# Altium schematic sheet 09  DT V1.0 V1.1.SchDoc
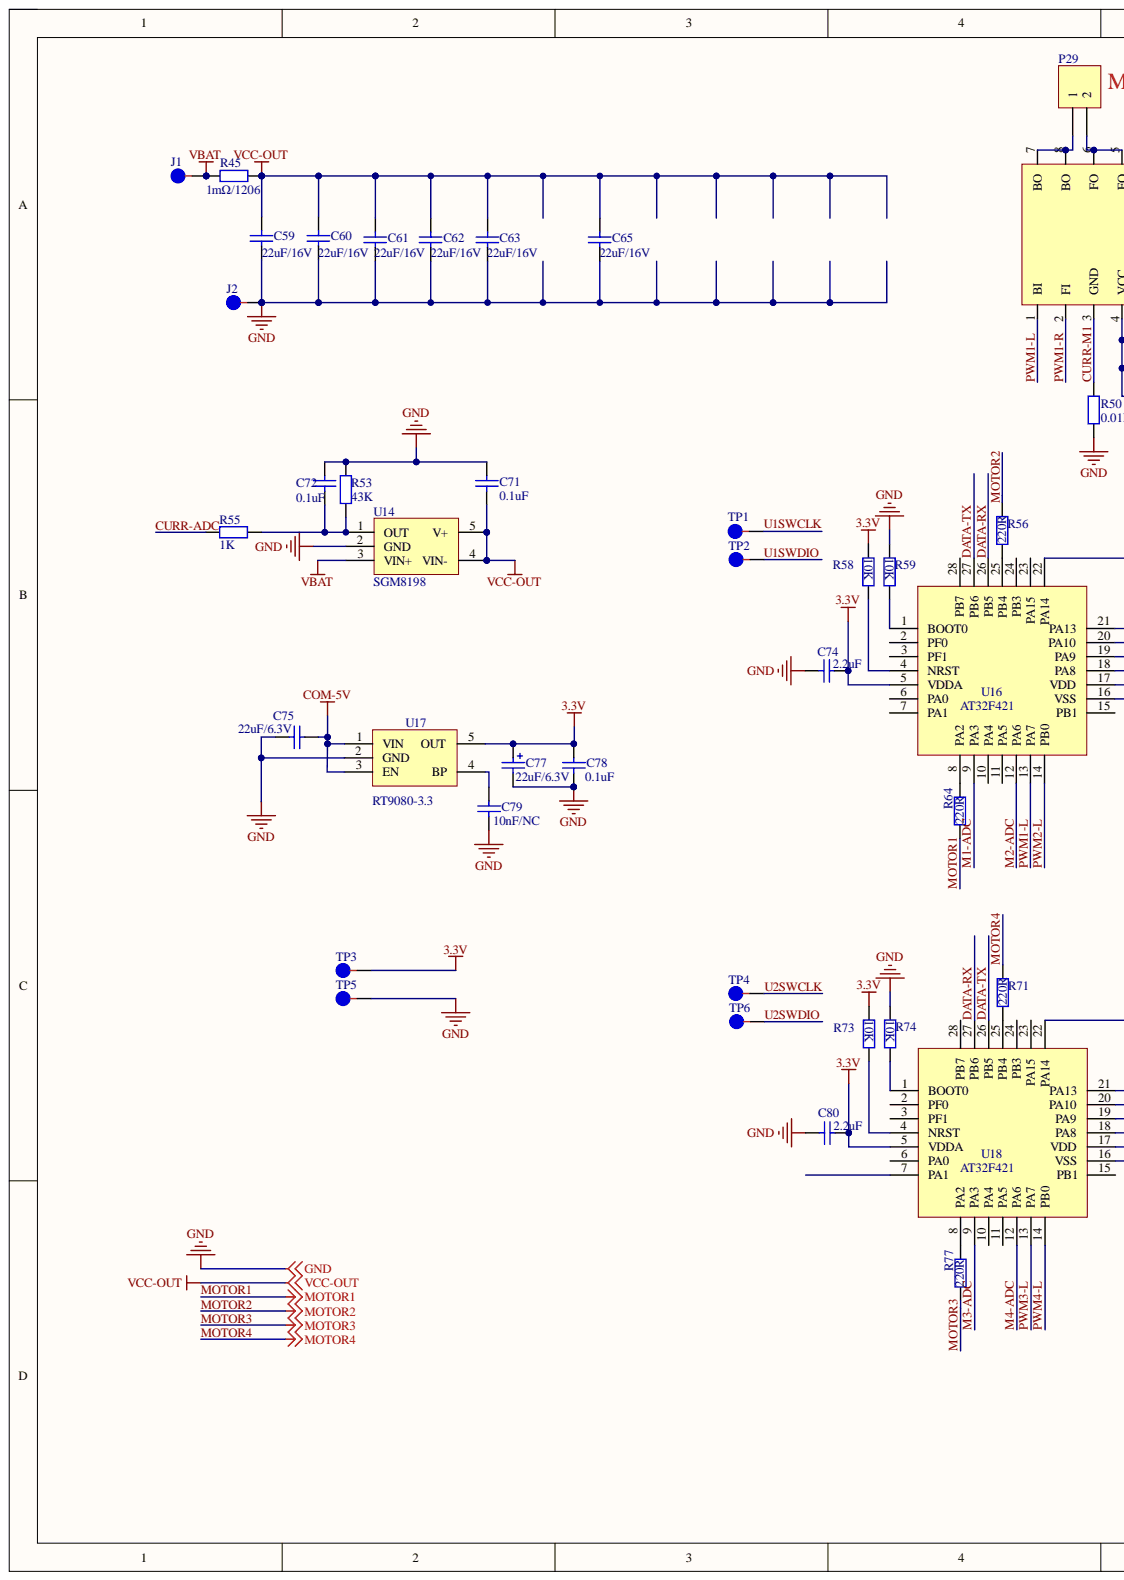
[diagram p0: 09  DT V1.0 V1.1.SchDoc, left]
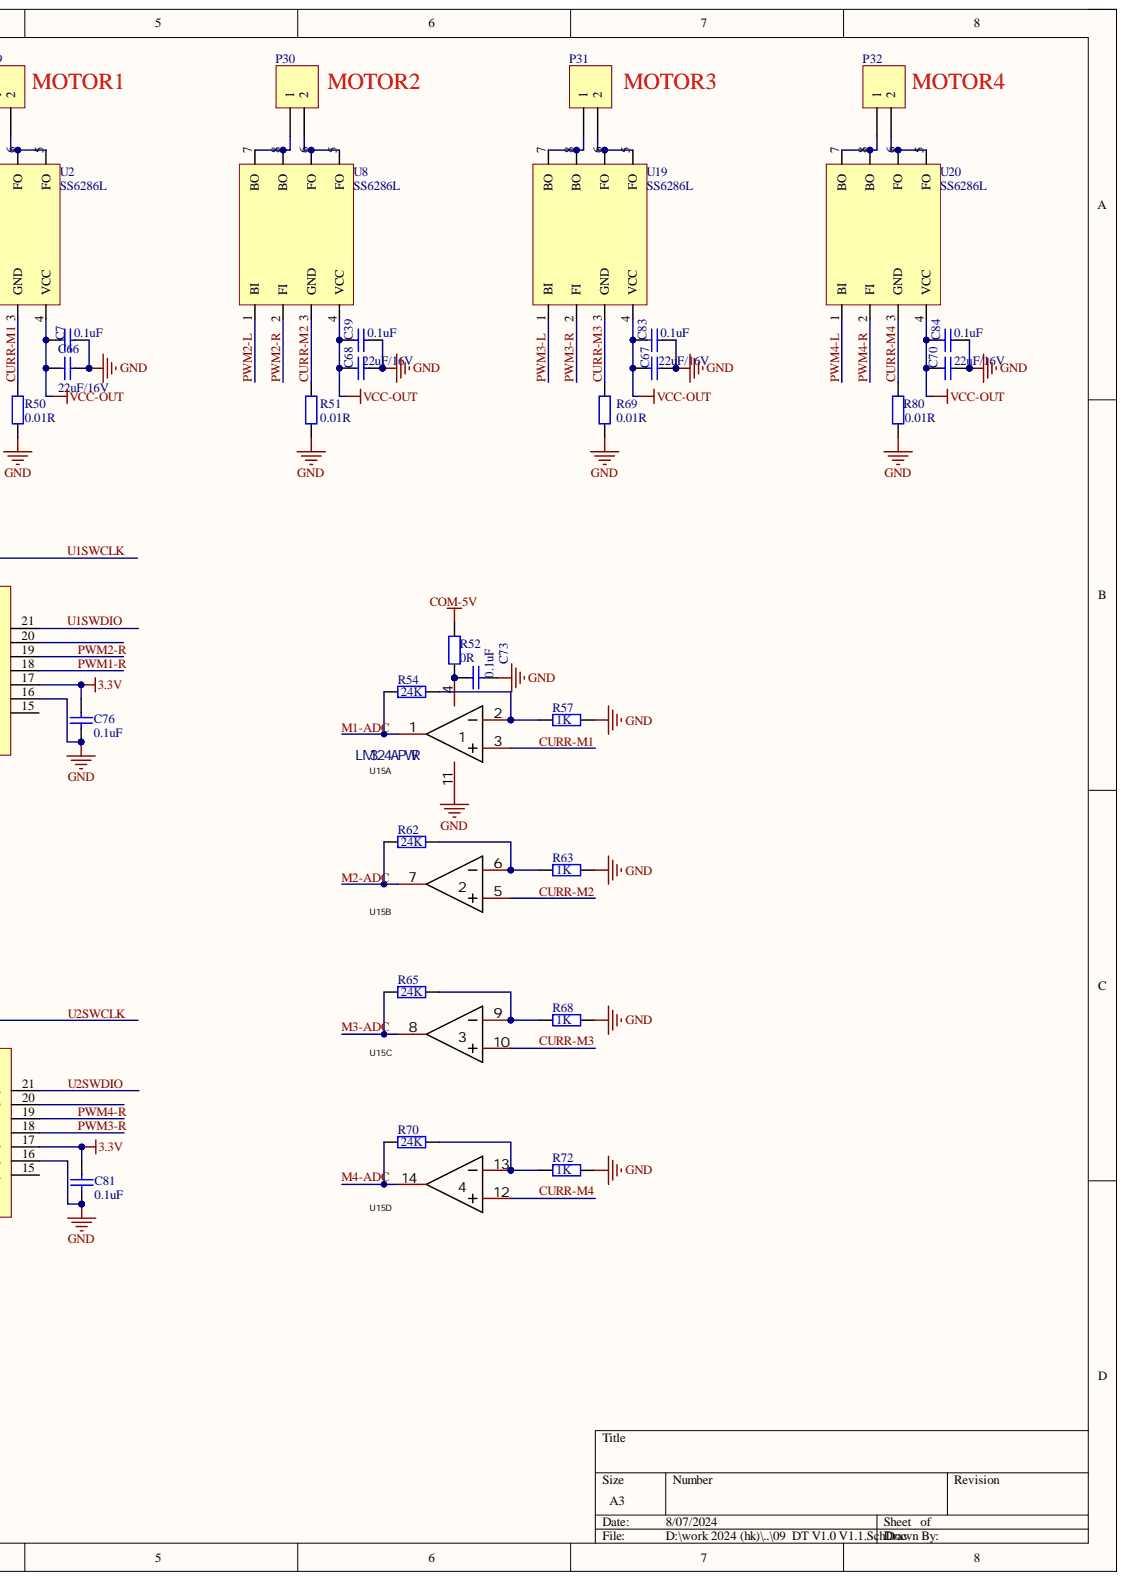
[diagram p1: 09  DT V1.0 V1.1.SchDoc, right]

## Parts
C7  0.1uF  [C0201]
C39  0.1uF  [C0201]
C59  22uF/16V  [C0603]
C60  22uF/16V  [C0603]
C61  22uF/16V  [C0603]
C62  22uF/16V  [C0603]
C63  22uF/16V  [C0603]
C65  22uF/16V  [C0603]
C66  22uF/16V  [C0603]
C67  22uF/16V  [C0603]
C68  22uF/16V  [C0603]
C70  22uF/16V  [C0603]
C71  0.1uF  [C0201]
C72  0.1uF  [C0201]
C73  0.1uF  [C0201]
C74  2.2uF  [C0201]
C75  22uF/6.3V  [C0603]
C76  0.1uF  [C0201]
C77  22uF/6.3V  [C0805-TAN]
C78  0.1uF  [C0201]
C79  10nF/NC  [C0201]
C80  2.2uF  [C0201]
C81  0.1uF  [C0201]
C83  0.1uF  [C0201]
C84  0.1uF  [C0201]
J1  (no value)  [TP08]
J2  (no value)  [TP08]
P29  Header 2  [SH1.25-2PLI]  (value not drawn)
P30  Header 2  [SH1.25-2PLI]  (value not drawn)
P31  Header 2  [SH1.25-2PLI]  (value not drawn)
P32  Header 2  [SH1.25-2PLI]  (value not drawn)
R45  1mΩ/1206  [R1206]
R50  0.01R  [R1206]
R51  0.01R  [R1206]
R52  0R  [R0201]
R53  43K  [R0201]
R54  24K  [R0201]
R55  1K  [R0201]
R56  220R  [R0201]
R57  1K  [R0201]
R58  10K  [R0201]
R59  10K  [R0201]
R62  24K  [R0201]
R63  1K  [R0201]
R64  220R  [R0201]
R65  24K  [R0201]
R68  1K  [R0201]
R69  0.01R  [R1206]
R70  24K  [R0201]
R71  220R  [R0201]
R72  1K  [R0201]
R73  10K  [R0201]
R74  10K  [R0201]
R77  220R  [R0201]
R80  0.01R  [R1206]
TP1  (no value)  [TP08]
TP2  (no value)  [TP08]
TP3  (no value)  [TP08]
TP4  (no value)  [TP08]
TP5  (no value)  [TP08]
TP6  (no value)  [TP08]
U2  SS6286L  [SS6286L-DFN8-4*4]
U8  SS6286L  [SS6286L-DFN8-4*4]
U14  SGM8198  [RT9193]
U15  LM324APWR  [TSSOP-14P]  (parts A,B,C,D)
U16  AT32F421  [QFN28-4X4]
U17  RT9080-3.3  [RT9193]
U18  AT32F421  [QFN28-4X4]
U19  SS6286L  [SS6286L-DFN8-4*4]
U20  SS6286L  [SS6286L-DFN8-4*4]

## Nets
3.3V: C74.1 C76.1 C77.1 C78.1 C80.1 C81.1 R58.1 R73.1 TP3.1 U16.5(VDDA) U16.17(VDD) U17.5(OUT) U18.5(VDDA) U18.17(VDD)
COM-5V: C75.1 R52.1 U17.1(VIN) U17.3(EN)
GND: C7.1 C39.1 C59.1 C60.1 C61.1 C62.1 C63.1 C65.1 C66.1 C67.1 C68.1 C70.1 C71.1 C72.1 C73.2 C74.2 C75.2 C76.2 C77.2 C78.2 C79.2 C80.2 C81.2 C83.1 C84.1 J2.1 R50.1 R51.1 R53.2 R57.1 R59.1 R63.1 R68.1 R69.1 R72.1 R74.1 R80.1 TP5.1 U14.2(GND) U15.11 U16.16(VSS) U17.2(GND) U18.16(VSS)
VBAT: J1.1 R45.1 U14.3(VIN+)
VCC-OUT: C7.2 C39.2 C59.2 C60.2 C61.2 C62.2 C63.2 C65.2 C66.2 C67.2 C68.2 C70.2 C71.2 C83.2 C84.2 R45.2 U2.4(VCC) U8.4(VCC) U14.4(VIN-) U14.5(V+) U19.4(VCC) U20.4(VCC)
CURR-ADC: R55.2
CURR-M1: R50.2 U2.3(GND) U15.3
CURR-M2: R51.2 U8.3(GND) U15.5
CURR-M3: R69.2 U15.10 U19.3(GND)
CURR-M4: R80.2 U15.12 U20.3(GND)
DATA-RX: U16.26(PB5) U18.27(PB6)
DATA-TX: U16.27(PB6) U18.26(PB5)
M1-ADC: R54.2 U15.1 U16.9(PA3)
M2-ADC: R62.2 U15.7 U16.12(PA6)
M3-ADC: R65.2 U15.8 U18.9(PA3)
M4-ADC: R70.2 U15.14 U18.12(PA6)
MOTOR1: R64.1
MOTOR2: R56.1
MOTOR3: R77.1
MOTOR4: R71.1
PWM1-L: U2.1(BI) U16.13(PA7)
PWM1-R: U2.2(FI) U16.18(PA8)
PWM2-L: U8.1(BI) U16.14(PB0)
PWM2-R: U8.2(FI) U16.19(PA9)
PWM3-L: U18.13(PA7) U19.1(BI)
PWM3-R: U18.18(PA8) U19.2(FI)
PWM4-L: U18.14(PB0) U20.1(BI)
PWM4-R: U18.19(PA9) U20.2(FI)
U1SWCLK: TP1.1 U16.22(PA14)
U1SWDIO: TP2.1 U16.21(PA13)
U2SWCLK: TP4.1 U18.22(PA14)
U2SWDIO: TP6.1 U18.21(PA13)
NetC72_2: C72.2 R53.1 R55.1 U14.1(OUT)
NetC73_1: C73.1 R52.2 U15.4
NetC79_1: C79.1 U17.4(BP)
NetP29_1: P29.1 U2.7(BO) U2.8(BO)
NetP29_2: P29.2 U2.5(FO) U2.6(FO)
NetP30_1: P30.1 U8.7(BO) U8.8(BO)
NetP30_2: P30.2 U8.5(FO) U8.6(FO)
NetP31_1: P31.1 U19.7(BO) U19.8(BO)
NetP31_2: P31.2 U19.5(FO) U19.6(FO)
NetP32_1: P32.1 U20.7(BO) U20.8(BO)
NetP32_2: P32.2 U20.5(FO) U20.6(FO)
NetR54_1: R54.1 R57.2 U15.2
NetR56_2: R56.2 U16.25(PB4)
NetR58_2: R58.2 U16.4(NRST)
NetR59_2: R59.2 U16.1(BOOT0)
NetR62_1: R62.1 R63.2 U15.6
NetR64_2: R64.2 U16.8(PA2)
NetR65_1: R65.1 R68.2 U15.9
NetR70_1: R70.1 R72.2 U15.13
NetR71_2: R71.2 U18.25(PB4)
NetR73_2: R73.2 U18.4(NRST)
NetR74_2: R74.2 U18.1(BOOT0)
NetR77_2: R77.2 U18.8(PA2)

Not connected: U16 2(PF0) 3(PF1) 6(PA0) 7(PA1) 10(PA4) 11(PA5) 15(PB1) 20(PA10) 23(PA15) 24(PB3) 28(PB7); U18 2(PF0) 3(PF1) 6(PA0) 7(PA1) 10(PA4) 11(PA5) 15(PB1) 20(PA10) 23(PA15) 24(PB3) 28(PB7)

## Library data of the parts
C7 C39 C73 C74 C76 C78-C81 C83 C84 = C0201: Capacitor; Component Kind: Standard; Library Name: Integrated_Library_gr.IntLib
C59-C63 C65-C68 C70 = C1206: Capacitor; Value: 22uF; Component Kind: Standard; Library Name: Integrated_Library_gr.IntLib
C71 C72 = C0402: Capacitor; Component Kind: Standard; Library Name: Integrated_Library_gr.IntLib; Value: 0.1uF
C75 = C0603: Capacitor; Component Kind: Standard; Library Name: Integrated_Library_gr.IntLib
C77 = DC1206: Capacitor; Component Kind: Standard; Library Name: Integrated_Library_gr.IntLib
J1 J2 TP1-TP6 = TP: Component Kind: Standard
P29-P32 = Header 2: Header, 2-Pin; Component Kind: Standard; LatestRevisionDate: 17-Jul-2002; LatestRevisionNote: Re-released for DXP Platform.; Library Name: Miscellaneous Connectors.IntLib; Signal Integrity: Connector; Publisher: Altium Limited
R45 R50 R51 R69 R80 = 0M30: Component Kind: Standard; Library Name: Integrated_Library_gr.IntLib
R52 R54 R56-R59 R62-R65 R68 R70-R74 R77 = R0201: Resistor; Component Kind: Standard
  R52 R56 R58 R59 R64 R71 R73 R74 R77: Library Name: Integrated_Library_gr.IntLib
  R54 R57 R62 R63 R65 R68 R70 R72: Library Name: AT32F421K8U7temp V1.0.SCHLIB
R53 R55 = R0402: Resistor; Component Kind: Standard; Library Name: Integrated_Library_gr.IntLib
U14 U17 = RT9193: IC; Component Kind: Standard; Library Name: Integrated_Library_gr.IntLib
U15 = LM324APWR: Manufacturer: TI(德州仪器); Add into BOM: yes; Current - Input Bias(Ib): 15nA; Gain Bandwidth Product(GBP): 1.2MHz; Number of Circuits: 四路; Output Current: 30mA; Slew Rate(SR): 0.5V/us; -3db Bandwidth: -; Component Kind: Standard; Convert to PCB: yes; Datasheet: https://www.ti.com/cn/lit/gpn/lm2902; Device: LM324APWR; JLCPCB Part Class: Extended Part; LCSC Part Name: LM324APWR; Library Name: AT32F421K8U7temp V1.0.SCHLIB; Manufacturer Part: LM324APWR; Operating Temperature: 0℃~+70℃; Supplier: LCSC; Supplier Footprint: TSSOP-14; Supplier Part: C350548; Symbol: LM324APWR
U16 U18 = STM32F350GD: Component Kind: Standard; Library Name: Integrated_Library_gr.IntLib
U2 U8 U19 U20 = SS6286L: Component Kind: Standard; Library Name: AT32F421K8U7temp V1.0.SCHLIB
